# Altium schematic sheet CRAFT_E_Stop_AJefferson.SchDoc(CRAFT_E_Stop_AJefferson)
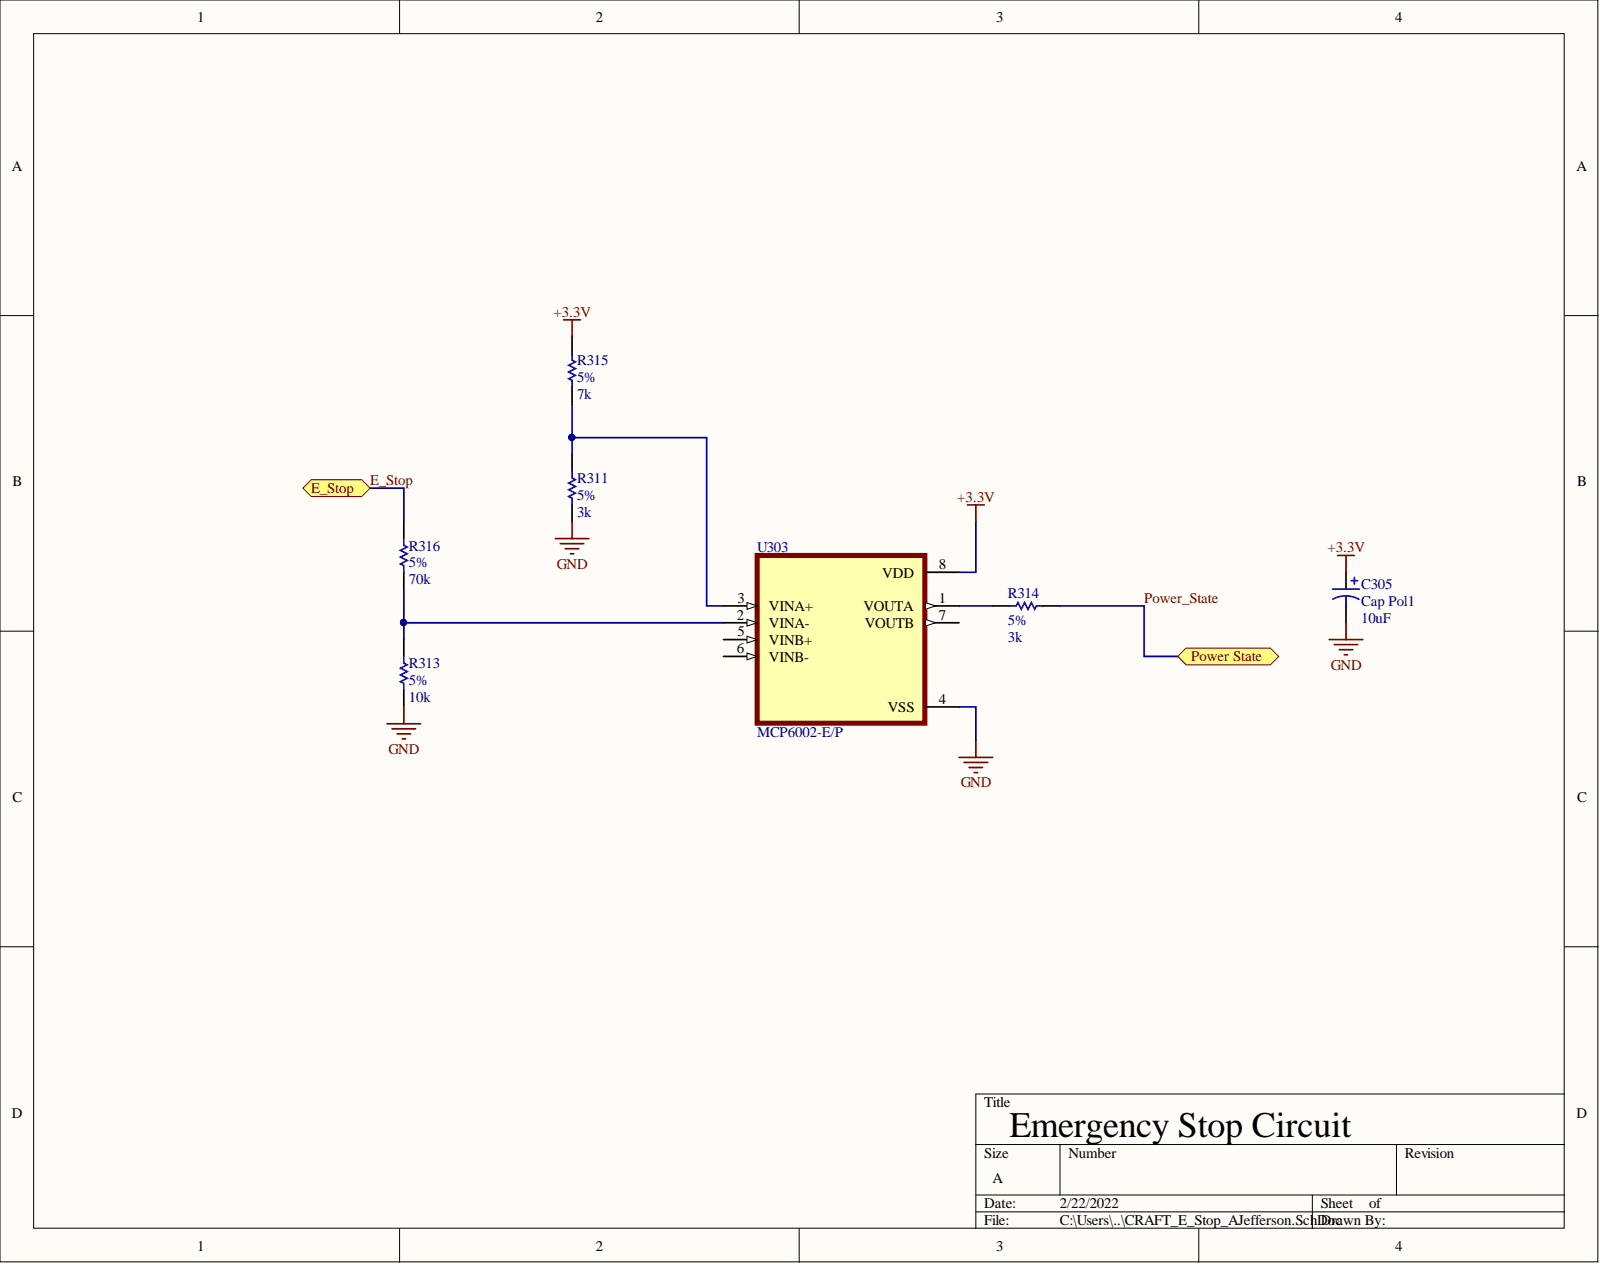
[diagram p0: CRAFT_E_Stop_AJefferson.SchDoc]

## Parts
C305  Cap Pol1  value 10uF  [CAPPRD250W50D630H1120]
R311  5%  value 3k  [AXIAL-0.3]
R313  5%  value 10k  [AXIAL-0.3]
R314  5%  value 3k  [AXIAL-0.3]
R315  5%  value 7k  [AXIAL-0.3]
R316  5%  value 70k  [AXIAL-0.3]
U303  MCP6002-E/P  [DIP787W46P254L927H533Q8]

## Ports
E_Stop, Power State

## Nets
+3.3V: C305.1 R315.2 U303.8(VDD)
GND: C305.2 R311.1 R313.1 U303.4(VSS)
E_Stop: R316.2 ; port E_Stop
Power_State: R314.2 ; port Power State
NetR311_2: R311.2 R315.1 U303.3(VINA+)
NetR313_2: R313.2 R316.1 U303.2(VINA-)
NetR314_1: R314.1 U303.1(VOUTA)

Not connected: U303 5(VINB+) 6(VINB-) 7(VOUTB)

## Library data of the parts
C305 = Cap Pol1: Polarized Capacitor (Radial); Simulation: CAP; Signal Integrity: Cap Pol; Published: 8-Jun-2000; PackageReference: RB7.6-15; PackageDescription: Polarized Capacitor (Radial); 2 Leads; Library Name: Miscellaneous Devices.IntLib; LatestRevisionNote: Re-released for DXP Platform.; LatestRevisionDate: 17-Jul-2002; Component Kind: Standard; Publisher: Altium Limited
R311 R313-R316 = Res1: Resistor; Simulation: RESISTOR; Signal Integrity: Res; Published: 8-Jun-2000; PackageReference: AXIAL-0.3; PackageDescription: Axial Device, Thru-Hole; 2 Leads; 0.3 in Pin Spacing; Library Name: Miscellaneous Devices.IntLib; LatestRevisionNote: Re-released for DXP Platform.; LatestRevisionDate: 17-Jul-2002; Component Kind: Standard; Publisher: Altium Limited
U303 = MCP6002-E/P: General Purpose Amplifier 2 Circuit Rail-to-Rail 8-PDIP; MF: Microchip; SnapEDA_Link: https://www.snapeda.com/parts/MCP6002-E/P/Microchip/view-part/228325/?ref=snap; Price: None; Package: DIP-8 Microchip; MP: MCP6002-E/P; Library Name: MCP6002-E_P.IntLib; Component Kind: Standard; Availability: Unavailable
